# Altium schematic sheet Power.SchDoc(Power)
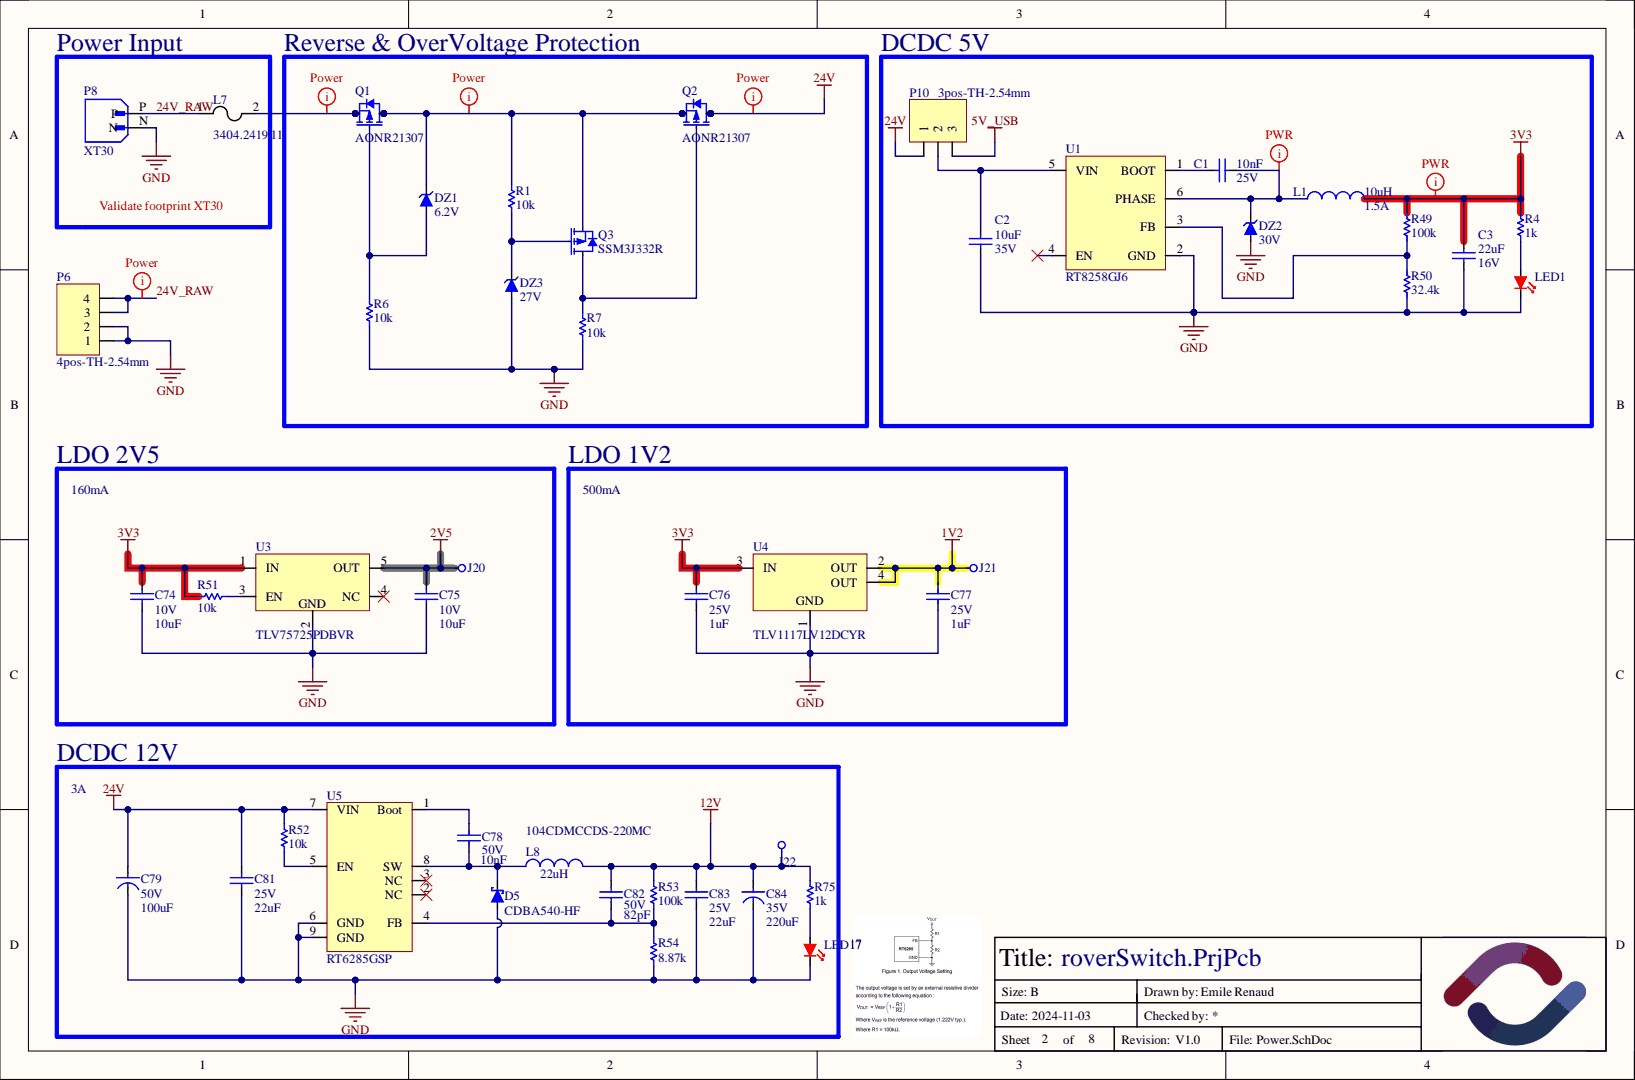
[diagram p0: Power.SchDoc]

## Parts
C1  value 10nF  Volt: 25V  [CAPACITOR-0603]  (hidden comment: Capacitor-0603-10nF-25V-X5R)
C2  value 10uF  Volt: 35V  [CAPACITOR-0805]  (hidden comment: Capacitor-0805-10uF-35V-X5R)
C3  value 22uF  Volt: 16V  [CAPACITOR-0805]  (hidden comment: Capacitor-0805-22uF-16V-X5R)
C74  value 10uF  Volt: 10V  [CAPACITOR-0603]  (hidden comment: Capacitor-0603-10uF-10V-X5R)
C75  value 10uF  Volt: 10V  [CAPACITOR-0603]  (hidden comment: Capacitor-0603-10uF-10V-X5R)
C76  value 1uF  Volt: 25V  [CAPACITOR-0603]  (hidden comment: Capacitor-0603-1uF-25V-X5R)
C77  value 1uF  Volt: 25V  [CAPACITOR-0603]  (hidden comment: Capacitor-0603-1uF-25V-X5R)
C78  value 10nF  Volt: 50V  [CAPACITOR-0603]  (hidden comment: Capacitor-0603-10nF-50V-X7R)
C79  value 100uF  Volt: 50V  [CAP_J-V_8X10P5_RUB]  (hidden comment: CAP ALUM 100UF 20% 25V SMD)
C81  value 22uF  Volt: 25V  [CAPACITOR-0805]  (hidden comment: Capacitor-0805-22uF-25V-X5R)
C82  value 82pF  Volt: 50V  [CAPACITOR-0603]  (hidden comment: Capacitor-0603-82pF-50V-C0G)
C83  value 22uF  Volt: 25V  [CAPACITOR-0805]  (hidden comment: Capacitor-0805-22uF-25V-X5R)
C84  value 220uF  Volt: 35V  [CAP_J-V_8X10P5_RUB]  (hidden comment: CAP ALUM 220UF 20% 35V SMD)
D5  CDBA540-HF  [SMA/DO-214AC]
DZ1  Volt: 6.2V  [SOD-123]  (hidden comment: BZT52C6V2-TP)
DZ2  Volt: 30V  [DO-219AD]  (hidden comment: MSS1P3L-M3/89A)
DZ3  Volt: 27V  [SOD-123F]  (hidden comment: MMSZ5254B-TP)
J20  hole  [PAD-0.53MM]  (value not drawn)  (no BOM)
J21  hole  [PAD-0.53MM]  (value not drawn)  (no BOM)
J22  hole  [PAD-0.53MM]  (value not drawn)  (no BOM)
L1  value 10uH  Current: 1.5A  [INDUCTOR_ASPI-4030S-100M]  (hidden comment: ASPI-4030S-100M-T)
L7  3404.2419.11  [2-SMD-Fuse]
L8  104CDMCCDS-220MC  value 22uH  [Inductor_22UH 5A]
LED1  B1911USD-20D000114U1930  [LED-0603-2PIN]  (value not drawn)
LED17  B1911USD-20D000114U1930  [LED-0603-2PIN]  (value not drawn)
P6  4pos-TH-2.54mm  [HDR1X4]
P8  XT30  [XT30PW_smd]
P10  3pos-TH-2.54mm  [HDR1X3]
Q1  AONR21307  [DFN8_3x3_Power]
Q2  AONR21307  [DFN8_3x3_Power]
Q3  SSM3J332R  [SOT-23-3]
R1  value 10k  [Resistor-0603]  (hidden comment: SMT resistor)
R4  value 1k  [Resistor-0603]  (hidden comment: SMT resistor)
R6  value 10k  [Resistor-0603]  (hidden comment: SMT resistor)
R7  value 10k  [Resistor-0603]  (hidden comment: SMT resistor)
R49  value 100k  [Resistor-0603]  (hidden comment: SMT resistor)
R50  value 32.4k  [Resistor-0603]  (hidden comment: SMT resistor)
R51  value 10k  [Resistor-0603]  (hidden comment: SMT resistor)
R52  value 10k  [Resistor-0603]  (hidden comment: SMT resistor)
R53  value 100k  [Resistor-0603]  (hidden comment: SMT resistor)
R54  value 8.87k  [Resistor-0603]  (hidden comment: SMT resistor)
R75  value 1k  [Resistor-0603]  (hidden comment: SMT resistor)
U1  RT8258GJ6  [SOT-23-6]
U3  TLV75725PDBVR  [SOT-23-5]
U4  TLV1117LV12DCYR  [SOT-223-3/TO-261-3S]
U5  RT6285GSP  [SOIC-8-EXPOSED-PAD]

## Nets
1V2: C77.2 J21.1 U4.2(OUT) U4.4(OUT)
2V5: C75.1 J20.1 U3.5(OUT)
3V3: C3.2 C74.1 C76.2 L1.2 R4.2 R49.2 R51.2 U3.1(IN) U4.3(IN)
5V_USB: P10.3
12V: C82.2 C83.2 C84.1 J22.1 L8.2 R53.2 R75.2
24V: C79.1 C81.2 P10.1 Q2.5 Q2.6 Q2.7 Q2.8 R52.2 U5.7(VIN)
GND: C2.1 C3.1 C74.2 C75.2 C76.1 C77.1 C79.2 C81.1 C83.1 C84.2 D5.1 DZ2.2 DZ3.1 LED1.1 LED17.1 P6.1 P6.2 P8.N R6.1 R7.1 R50.1 R54.1 U1.2(GND) U3.2 U4.1 U5.6(GND) U5.9(GND)
24V_RAW: L7.1 P6.3 P6.4 P8.P
NetC1_1: C1.1 U1.1(BOOT)
NetC1_2: C1.2 DZ2.1 L1.1 U1.6(PHASE)
NetC2_2: C2.2 P10.2 U1.5(VIN)
NetC78_1: C78.1 D5.2 L8.1 U5.8(SW)
NetC78_2: C78.2 U5.1(Boot)
NetC82_1: C82.1 R53.1 R54.2 U5.4(FB)
NetDZ1_1: DZ1.1 Q1.4 R6.2
NetDZ1_2: DZ1.2 Q1.5 Q1.6 Q1.7 Q1.8 Q2.1 Q2.2 Q2.3 Q3.2 R1.2
NetDZ3_2: DZ3.2 Q3.1 R1.1
NetL7_2: L7.2 Q1.1 Q1.2 Q1.3
NetLED1_2: LED1.2 R4.1
NetLED17_2: LED17.2 R75.1
NetQ2_4: Q2.4 Q3.3 R7.2
NetR49_1: R49.1 R50.2 U1.3(FB)
NetR51_1: R51.1 U3.3(EN)
NetR52_1: R52.1 U5.5(EN)

Not connected: U1 4(EN); U3 4(NC); U5 2(NC) 3(NC)

## Library data of the parts
C1 = Capacitor-0603-10nF-25V-X5R: 10000 pF ±10% 25V Ceramic Capacitor X5R 0603 (1608 Metric); Precision: X5R-10%; Component Kind: Standard; Library Name: Capacitors.SchLib; Mounted: Yes; Package / Case: Metric 1608 , EIA 0603; Supplier 1: Digikey; Supplier Part Number 1: 478-KGM15AR51E103KTCT-ND
C2 = Capacitor-0805-10uF-35V-X5R: Precision: X5R-10%; Component Kind: Standard; Description: Capacitor-0805-10uF-35V-X5R; Library Name: Capacitors.SchLib; Mounted: Yes; Package / Case: Metric 2012 , EIA 0805; Supplier 1: Digi-Key; Supplier 2: Digi-Key; Supplier 3: Digi-Key; Supplier 4: Digi-Key; Supplier Part Number 1: 490-10515-1-ND
C3 = Capacitor-0805-22uF-16V-X5R: Precision: X5R-10%; Component Kind: Standard; Description: Capacitor-0805-22uF-16V-X5R; Library Name: Capacitors.SchLib; Mounted: Yes; Package / Case: Metric 2012 , EIA 0805; Supplier 1: Digi-Key; Supplier 2: Digi-Key; Supplier 3: Digi-Key; Supplier 4: Digi-Key; Supplier Part Number 1: 1276-6780-1-ND
C74 C75 = Capacitor-0603-10uF-10V-X5R: 10 µF ±20% 10V Ceramic Capacitor X5R 0603 (1608 Metric); Component Kind: Standard; Library Name: Capacitors.SchLib; Mounted: Yes; Package / Case: Metric 1608 , EIA 0603; Precision: 20%; Supplier 1: Digikey; Supplier Part Number 1: 4713-GMC10X5R106M10NTCT-ND
C76 C77 = Capacitor-0603-1uF-25V-X5R: Precision: X5R-10%; Component Kind: Standard; Description: Capacitor-0603-1uF-25V-X5R; Library Name: Capacitors.SchLib; Mounted: Yes; Package / Case: Metric 1608, EIA 0603; Supplier 1: Digi-Key; Supplier 2: Digi-Key; Supplier Part Number 1: 1276-1859-2-ND; Supplier Part Number 2: 1276-1942-2-ND
C78 = Capacitor-0603-10nF-50V-X7R: Precision: X7R-10%; Component Kind: Standard; Description: Capacitor-0603-10nF-50V-X7R; Library Name: Capacitors.SchLib; Mounted: Yes; Package / Case: Metric 1608 , EIA 0603; Supplier 1: Digikey; Supplier Part Number 1: 490-4778-1-ND
C79 = Capacitor-100uF-50V-SMD: CAP ALUM 100UF 20% 50V SMD; Component Kind: Standard; Library Name: Capacitors-Electrolytic.SchLib; Mounted: Yes; Precision: 20%; Supplier 1: Digi-Key; Supplier 2: Digi-Key; Supplier Part Number 1: 1189-1651-1-ND
C81 C83 = Capacitor-0805-22uF-25V-X5R: Precision: X5R-20%; Component Kind: Standard; Description: Capacitor-0805-22uF-25V-X5R; Library Name: Capacitors.SchLib; Mounted: Yes; Package / Case: Metric 2012 , EIA 0805; Supplier 1: Digi-Key; Supplier 2: Digi-Key; Supplier Part Number 1: 490-12389-1-ND
C82 = Capacitor-0603-82pF-50V-C0G: Precision: C0G-5%; Component Kind: Standard; Description: Capacitor-0603-82pF-50V-C0G; Library Name: Capacitors.SchLib; Mounted: Yes; Package / Case: Metric 1608, EIA 0603; Supplier 1: Digi-Key; Supplier 2: Digi-Key; Supplier Part Number 1: 478-KGM15ACG1H820JTCT-ND
C84 = Capacitor-220uF-35V-SMD: Component Kind: Standard; Description: CAP ALUM 220UF 20% 35V SMD; Library Name: Capacitors-Electrolytic.SchLib; Mounted: Yes; Precision: 20%; Supplier 1: Digi-Key; Supplier 2: Digi-Key; Supplier Part Number 1: 1189-1651-1-ND
D5 = CDBA540-HF: DIODE SCHOTTKY 40V 5A SMA; Component Kind: Standard; Library Name: diodes.SchLib; Mounted: Yes; Package / Case: SMA; Supplier 1: Digi-Key; Supplier Part Number 1: 641-1707-1-ND; Volt: 40V
DZ1 = BZT52C6V2-TP: Zener Diode 6.2 V 200 mW ±6% Surface Mount SOD-123; Grade: None; Watt: 200mW; Component Kind: Standard; Library Name: diodes-zener.SchLib; Mounted: Yes; Package / Case: SOD-123; Precision: 6%; Supplier 1: Digi-Key; Supplier Part Number 1: BZT52C13-TPMSCT-ND
DZ2 = MSS1P3L-M3/89A: Diode 30 V 1A Surface Mount MicroSMP (DO-219AD); Grade: None; Component Kind: Standard; Library Name: diodes-zener.SchLib; Mounted: Yes; Package / Case: DO-214AC, SMA; Supplier 1: Digi-Key; Supplier Part Number 1: MSS1P3L-M3/89AGICT-ND
DZ3 = MMSZ5254B-TP: DIODE ZENER 27V 500MW SOD123; Grade: None; Precision: 5%; Watt: 0.5W; Component Kind: Standard; Library Name: diodes-zener.SchLib; Mounted: Yes; Package / Case: SOD-123; Supplier 1: Digi-Key; Supplier Part Number 1: MMSZ5254B-TPMSCT-ND
J20-J22 = PAD 0.53mm AWG28-Solid: PAD for 28 AWG Solid Wire; Library Name: JumpersAndHoles.SchLib; Mounted: N/A
L1 = ASPI-4030S-100M-T: 10 µH Shielded Drum Core, Wirewound Inductor 1.5 A 100mOhm Nonstandard; Precision: 20%; Component Kind: Standard; Library Name: Inductors.SchLib; Mounted: Yes; Package / Case: SMD; Supplier 1: Digi-Key; Supplier Part Number 1: 535-12314-2-ND
L7 = 3404.2419.11: 2 A 277 V AC 250 V DC Fuse Board Mount (Cartridge Style Excluded) Requires Holder, Surface Mount 2-SMD, Square End Block with Clip; Current: 2A; Component Kind: Standard; Library Name: Fuses.SchLib; Mounted: Yes; Package / Case: SMD; Supplier 1: Digi-Key; Supplier Part Number 1: 486-2091-ND
L8 = 104CDMCCDS-220MC: FIXED IND 22UH 5A 66 MOHM SMD; Precision: 20%; Component Kind: Standard; Current: 5A; Library Name: Inductors.SchLib; Mounted: Yes; Package / Case: SMD; Supplier 1: Digi-Key; Supplier Part Number 1: 308-2498-1-ND
LED1 LED17 = B1911USD-20D000114U1930: LED RED CLEAR 1608 SMD; Component Kind: Standard; Library Name: LEDs.SchLib; Mounted: Yes; Package / Case: 0603 (1608 Metric); Supplier 1: Digi-Key; Supplier Part Number 1: 3147-B1911USD-20D000114U1930CT-ND
P6 = 4pos-TH-2.54mm: 4pos TH 2.54mm Header; Component Kind: Standard; Library Name: Header.SchLib; Mounted: Yes; Package / Case: TH; Supplier 1: Digi-Key; Supplier Part Number 1: N/A; Volt: N/A
P8 = XT30_pcb: Component Kind: Standard; Description: XT30; Mounted: Yes; Package / Case: TH; Supplier 1: Digi-Key; Volt: N/A; Library Name: BatteriesConnector.SchLib
P10 = 3pos-TH-2.54mm: 3pos TH 2.54mm Header; Component Kind: Standard; Library Name: Header.SchLib; Mounted: Yes; Package / Case: TH; Supplier 1: Digi-Key; Supplier Part Number 1: N/A; Volt: N/A
Q1 Q2 = AONR21307: MOSFET P-CH 30V 24A 8DFN; Component Kind: Standard; Current: 24A; Library Name: FET-P-Small.SchLib; Mounted: Yes; Package / Case: 8-DFN-EP (3x3); Supplier 1: Digi-Key; Supplier Part Number 1: 785-1796-2-ND; Volt: 30V
Q3 = SSM3J332R: P-Channel 30 V 6A (Ta) 1W (Ta) Surface Mount SOT-23F; Volt: 30V; Component Kind: Standard; Current: 6A; Library Name: FET-P-Small.SchLib; Mounted: Yes; Package / Case: SOT-523; Supplier 1: Digi-Key; Supplier Part Number 1: SSM3J332RLFCT-ND
R1 R6 R7 R51 = Resistor0603-10k: Precision: 1%; Watt: 0.1W; Component Kind: Standard; Description: Resistor0603-10k; Library Name: Resistors.SchLib; Mounted: Yes; Package / Case: Metric 1608, EIA 0603; Supplier 1: Digi-Key; Supplier 2: Digi-Key; Supplier 3: Digi-Key; Supplier 4: Digi-Key; Supplier Part Number 1: 311-10.0KHRTR-ND; Supplier Part Number 2: RMCF0603FT10K0TR-ND
R4 R75 = Resistor-0603-1k: Resistor0603-1k; Precision: 1%; Watt: 0.1W; Component Kind: Standard; Library Name: Resistors.SchLib; Mounted: Yes; Package / Case: Metric 1608, EIA 0603; Supplier 1: Digi-Key; Supplier Part Number 1: 311-1.00KHRCT-ND
R49 R53 = Resistor-0603-100k: Resistor0603-100k; Precision: 1%; Watt: 0.1W; Component Kind: Standard; Library Name: Resistors.SchLib; Mounted: Yes; Package / Case: Metric 1608, EIA 0603; Supplier 1: Digi-Key; Supplier Part Number 1: 311-100KHRCT-ND
R50 = Resistor-0603-32.4k: Resistor0603-32.4k; Precision: 1%; Watt: 0.1W; Component Kind: Standard; Library Name: Resistors.SchLib; Mounted: Yes; Package / Case: Metric 1608, EIA 0603; Supplier 1: Digi-Key; Supplier Part Number 1: 311-32.4KHRCT-ND
R52 = Resistor-0603-10k: Resistor0603-10k; Precision: 1%; Watt: 0.1W; Component Kind: Standard; Library Name: Resistors.SchLib; Mounted: Yes; Package / Case: Metric 1608, EIA 0603; Supplier 1: Digi-Key; Supplier Part Number 1: 311-10.0KHRTR-ND
R54 = Resistor-0603-8.87k: Resistor0603-8.87k; Precision: 1%; Watt: 0.1W; Component Kind: Standard; Library Name: Resistors.SchLib; Mounted: Yes; Package / Case: Metric 1608, EIA 0603; Supplier 1: Digi-Key; Supplier Part Number 1: 311-8.87KHRCT-ND
U1 = REG_SWR_RT8258GJ6: Switching Regulator/Controller; Manufacturer: Richtek; Category: Voltage Regulators - Switching; Component Kind: Standard; ComponentLink1Description: https://datasheet.ciiva.com:443/pdfs/VipMasterIC/IC/RHTK/RHTKS00677/RHTKS00677-1.pdf?src-supplier=IHS+Markit; ComponentLink1URL: https://datasheet.ciiva.com:443/pdfs/VipMasterIC/IC/RHTK/RHTKS00677/RHTKS00677-1.pdf?src-supplier=IHS+Markit; ComponentLink2Description: https://datasheet.ciiva.com/2035/3d3futf-83fb3frfm4mju4ltaylnbkzg3d3d3f3d-2035878.pdf?src-supplier=Digikey; ComponentLink2URL: https://datasheet.ciiva.com/2035/3d3futf-83fb3frfm4mju4ltaylnbkzg3d3d3f3d-2035878.pdf?src-supplier=Digikey; ComponentLink3Description: https://datasheet.ciiva.com/22686/1720507-22686356.pdf?src-supplier=Element14; ComponentLink3URL: https://datasheet.ciiva.com/22686/1720507-22686356.pdf?src-supplier=Element14; Manufacturer Part Number: RT8258GJ6; Pricing: 1=0,64, 10=0,566, 50=0,566, 100=0,434, 1000=0,274, 10000=0,223 (USD); RoHS: Yes; Stock: 6840; Supplier: Mouser; Supplier Part Number: 835-RT8258GJ6; Library Name: PowerKohrd.SchLib
U3 = TLV75725PDBVR: IC REG 2.5V Positive Fixed 1 Output 1A SOT-23-5; Component Kind: Standard; Library Name: PMIC-VoltageRegulators-LinearRegulators.SchLib; Mounted: Yes; Package / Case: SOT23-5; Supplier 1: Digi-Key; Supplier Part Number 1: 296-50412-1-ND
U4 = TLV1117LV12DCYR: IC REG 1.2V LINEAR 1A SOT223; Component Kind: Standard; Library Name: PMIC-VoltageRegulators-LinearRegulators.SchLib; Mounted: Yes; Package / Case: SOT223; Supplier 1: Digi-Key; Supplier Part Number 1: 296-TLV1117LV12DCYRCT-ND
U5 = RT6285GSP: 5A Buck Switching Regulator IC Positive Adjustable 1.222V 1 Output 5A 8-SOIC (0.154", 3.90mm Width) Exposed Pad; Grade: None; Component Kind: Standard; Library Name: PMIC-VoltageRegulators-DCDCSwitchingRegulators.SchLib; Mounted: Yes; Package / Case: 8-SOIC-EP; Supplier 1: Digi-Key; Supplier Part Number 1: 1028-RT6285GSPCT-ND; HelpURL: file:///C:/Users/Emile/Downloads/DS6285-00.pdf
